# Altium schematic sheet nanoSTM32.SchDoc
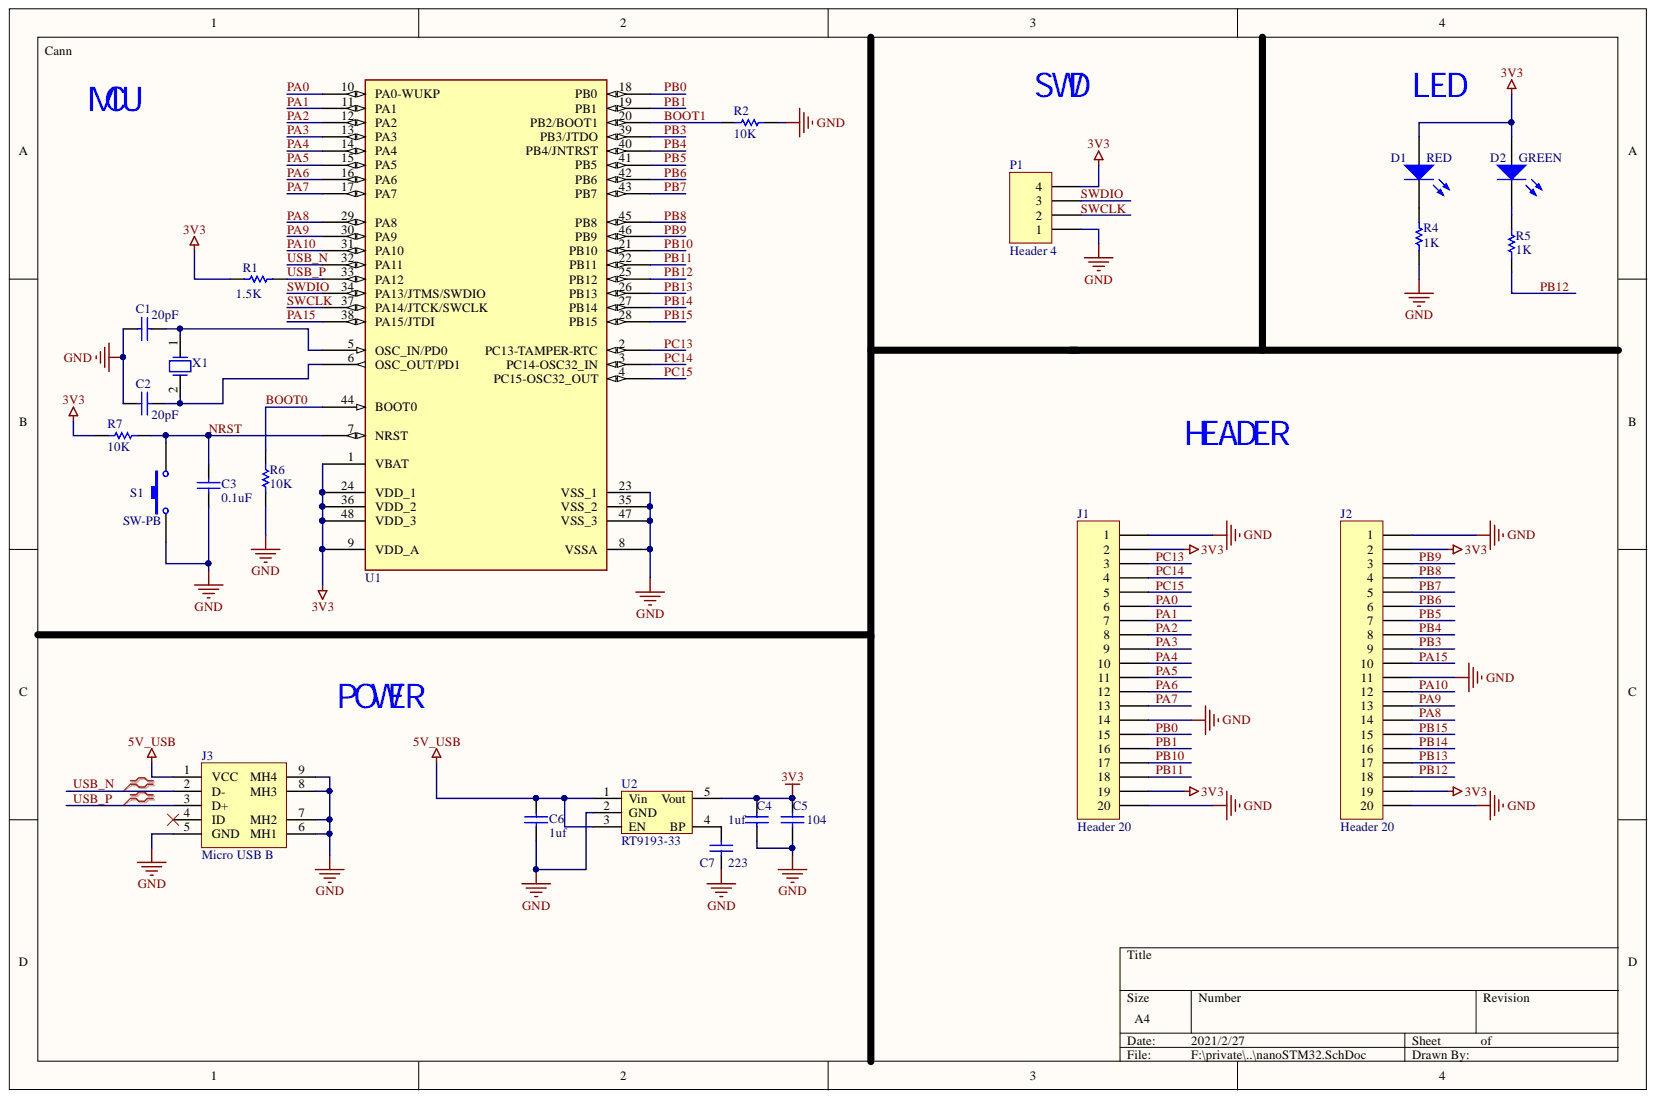
[diagram p0: nanoSTM32.SchDoc]

## Parts
C1  value 20pF  [0603_C]  (hidden comment: C0603)
C2  value 20pF  [0603_C]  (hidden comment: C0603)
C3  value 0.1uF  [0603_C]  (hidden comment: C0603)
C4  1uf  [0603_C]
C5  104  [0603_C]
C6  1uf  [0603_C]
C7  223  [0603_C]
D1  RED  [0603_LED]
D2  GREEN  [0603_LED]
J1  Header 20  [HEADER_20x1]
J2  Header 20  [HEADER_20x1]
J3  Micro USB B  [MICRO_USB]
logo  logo  (value not drawn)
P1  Header 4  [HEADER_4x1]
R1  value 1.5K  [0603_R]  (hidden comment: R0603)
R2  value 10K  [0603_R]  (hidden comment: R0603)
R4  value 1K  [0603_R]  (hidden comment: R0603)
R5  value 1K  [0603_R]  (hidden comment: R0603)
R6  value 10K  [0603_R]  (hidden comment: R0603)
R7  value 10K  [0603_R]  (hidden comment: R0603)
S1  SW-PB  [SMT_SW_PTS_820]
U1  STM32F103C8T6  [LQFP48_N]  (value not drawn)
U2  RT9193-33  [SOT23-5]
X1  XTAL  [49SMD]  (value not drawn)

## Nets
3V3: C4.2 C5.2 D1.1 D2.1 J1.2 J1.19 J2.2 J2.19 P1.4 R1.2 R7.2 U1.1(VBAT) U1.9(VDD_A) U1.24(VDD_1) U1.36(VDD_2) U1.48(VDD_3) U2.5(Vout)
5V_USB: C6.2 J3.1(VCC) U2.1(Vin) U2.3(EN)
GND: C1.2 C2.2 C3.1 C4.1 C5.1 C6.1 C7.1 J1.1 J1.14 J1.20 J2.1 J2.11 J2.20 J3.5(GND) J3.6(MH1) J3.7(MH2) J3.8(MH3) J3.9(MH4) P1.1 R2.1 R4.1 R6.2 S1.1 U1.8(VSSA) U1.23(VSS_1) U1.35(VSS_2) U1.47(VSS_3) U2.2(GND)
BOOT0: R6.1 U1.44(BOOT0)
BOOT1: R2.2 U1.20(PB2/BOOT1)
NRST: C3.2 R7.1 S1.2 U1.7(NRST)
PA0: J1.6 U1.10(PA0-WUKP)
PA1: J1.7 U1.11(PA1)
PA2: J1.8 U1.12(PA2)
PA3: J1.9 U1.13(PA3)
PA4: J1.10 U1.14(PA4)
PA5: J1.11 U1.15(PA5)
PA6: J1.12 U1.16(PA6)
PA7: J1.13 U1.17(PA7)
PA8: J2.14 U1.29(PA8)
PA9: J2.13 U1.30(PA9)
PA10: J2.12 U1.31(PA10)
PA15: J2.10 U1.38(PA15/JTDI)
PB0: J1.15 U1.18(PB0)
PB1: J1.16 U1.19(PB1)
PB3: J2.9 U1.39(PB3/JTDO)
PB4: J2.8 U1.40(PB4/JNTRST)
PB5: J2.7 U1.41(PB5)
PB6: J2.6 U1.42(PB6)
PB7: J2.5 U1.43(PB7)
PB8: J2.4 U1.45(PB8)
PB9: J2.3 U1.46(PB9)
PB10: J1.17 U1.21(PB10)
PB11: J1.18 U1.22(PB11)
PB12: J2.18 R5.1 U1.25(PB12)
PB13: J2.17 U1.26(PB13)
PB14: J2.16 U1.27(PB14)
PB15: J2.15 U1.28(PB15)
PC13: J1.3 U1.2(PC13-TAMPER-RTC)
PC14: J1.4 U1.3(PC14-OSC32_IN)
PC15: J1.5 U1.4(PC15-OSC32_OUT)
SWCLK: P1.2 U1.37(PA14/JTCK/SWCLK)
SWDIO: P1.3 U1.34(PA13/JTMS/SWDIO)
USB_N: J3.2(D-) U1.32(PA11)
USB_P: J3.3(D+) R1.1 U1.33(PA12)
NetC1_1: C1.1 U1.5(OSC_IN/PD0) X1.1
NetC2_1: C2.1 U1.6(OSC_OUT/PD1) X1.2
NetC7_2: C7.2 U2.4(BP)
NetD1_2: D1.2 R4.2
NetD2_2: D2.2 R5.2

Not connected: J3 4(ID)

## Library data of the parts
C1-C3 = C0603: Capacitor; Component Kind: Standard; LatestRevisionDate: 17-Jul-2002; LatestRevisionNote: Re-released for DXP Platform.; Library Name: MYLIB.IntLib; PackageDescription: Radial Cap, Thru-Hole; 2 Leads; 0.3 in Pin Spacing; PackageReference: RAD-0.3; Published: 8-Jun-2000; Signal Integrity: Cap; Simulation: CAP; Publisher: Altium Limited
C4 C6 C7 = CAP: Capacitor; Component Kind: Standard; Library Name: Cheetie Integrated Library 2017.IntLib
  C4 C6: value: 1uF
  C7: value: 223
C5 = CAP: Capacitor; value: 0.1uF; Component Kind: Standard; Library Name: Cheetie Integrated Library 2017.IntLib
D1 D2 = D0603: Typical RED, GREEN, YELLOW, AMBER GaAs LED; Component Kind: Standard; LatestRevisionDate: 17-Jul-2002; LatestRevisionNote: Re-released for DXP Platform.; Library Name: MYLIB.IntLib; PackageDescription: SM LED; 2 Flat Leads; PackageReference: 3.2X1.6X1.1; PackageVersion: Feb-2002; Published: 8-Jun-2000; Simulation: LED2; Publisher: Altium Limited
P1 = Header 4: Header, 4-Pin; Component Kind: Standard; LatestRevisionDate: 17-Jul-2002; LatestRevisionNote: Re-released for DXP Platform.; Library Name: Miscellaneous Connectors.IntLib; Signal Integrity: Connector; Publisher: Altium Limited
R1 R2 R4-R7 = R0603: Resistor; Component Kind: Standard; LatestRevisionDate: 17-Jul-2002; LatestRevisionNote: Re-released for DXP Platform.; Library Name: MYLIB.IntLib; PackageDescription: Axial Device, Thru-Hole; 2 Leads; 0.3 in Pin Spacing; PackageReference: AXIAL-0.3; Published: 8-Jun-2000; Signal Integrity: Res; Simulation: RESISTOR; Publisher: Altium Limited
S1 = SW-PB: Switch; Component Kind: Standard; LatestRevisionDate: 17-Jul-2002; LatestRevisionNote: Re-released for DXP Platform.; Library Name: Miscellaneous Devices.IntLib; PackageDescription: Switch; 2 Leads; PackageReference: SPST-2; Published: 24-Mar-1999; Publisher: Altium Limited
U1 = STM32F103C8T6: LowPower MCU; Component Kind: Standard; Library Name: MYLIB.IntLib
X1 = XTAL: value: 8MHz; Component Kind: Standard; Library Name: MYLIB.IntLib
J1 J2 = Header 20: Component Kind: Standard; Library Name: nanoSTM32.SCHLIB
J3 = Micro USB B: Component Kind: Standard; Library Name: nanoSTM32.SCHLIB
logo = logo: Component Kind: Standard; Library Name: nanoSTM32.SCHLIB
U2 = RT9193-33: Component Kind: Standard; Library Name: Cheetie Integrated Library 2017.IntLib
